# Altium schematic sheet SoC.SchDoc(SoC)
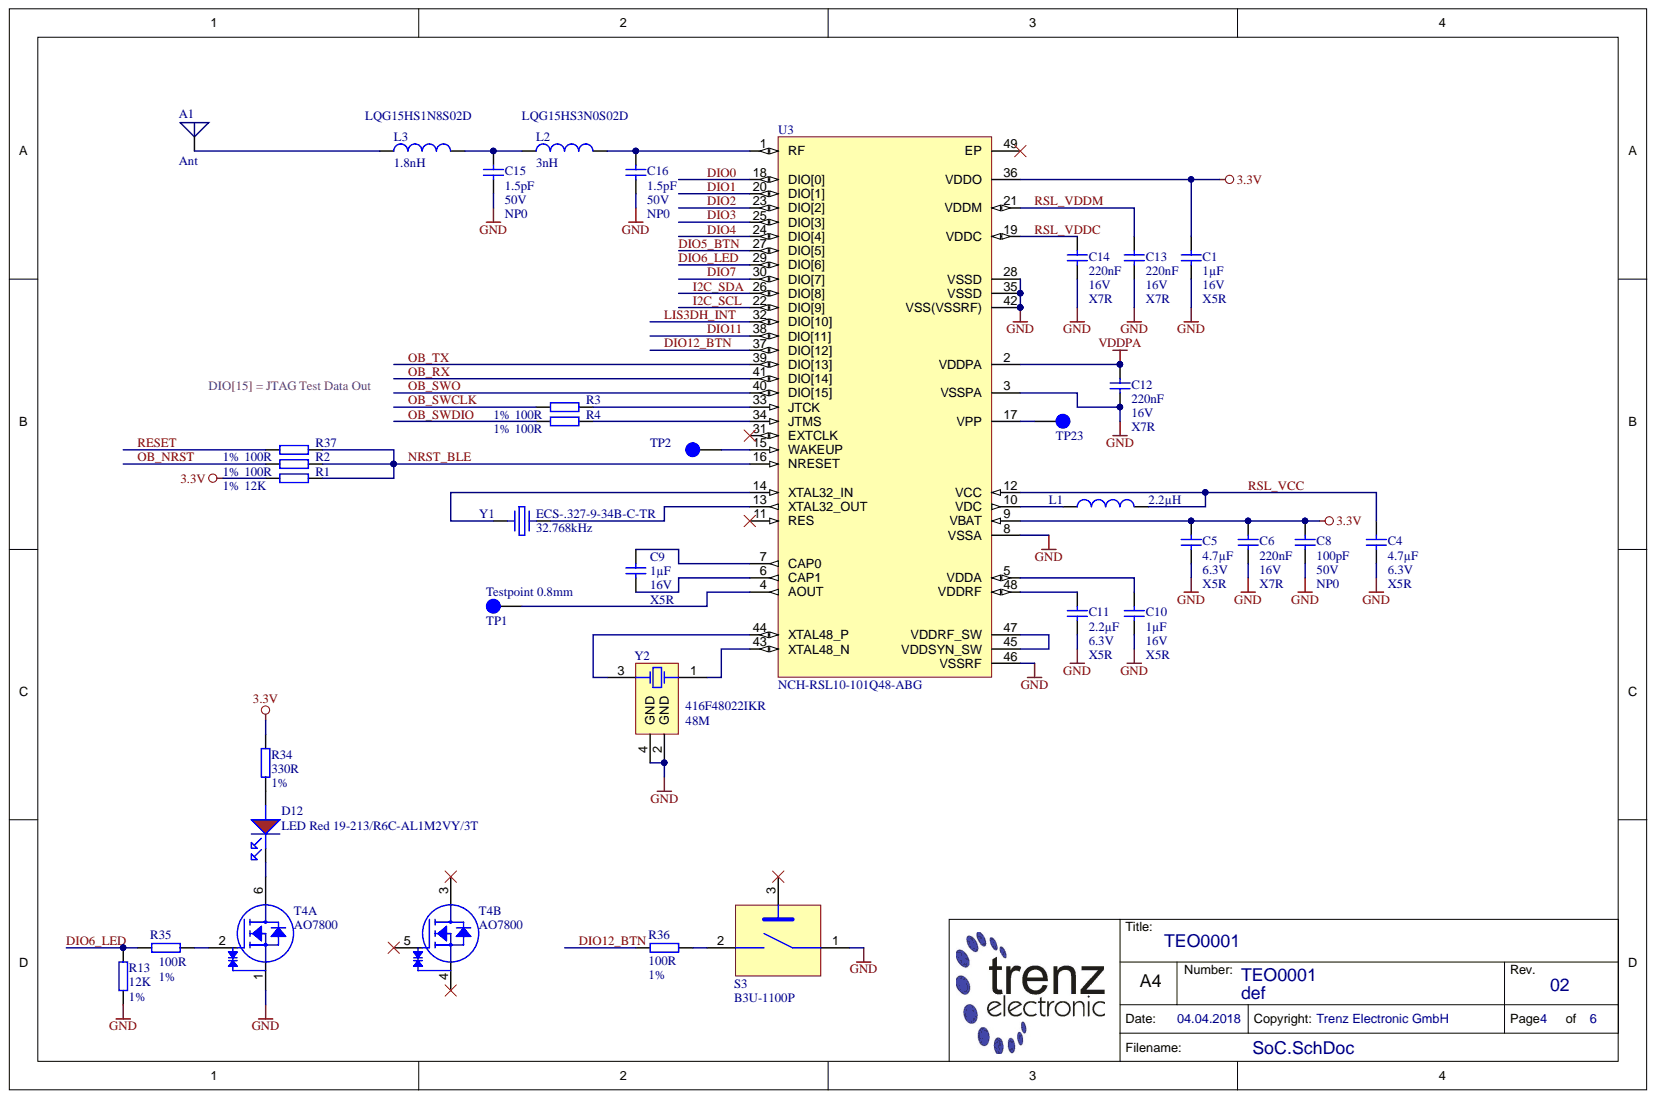
[diagram p0: SoC.SchDoc]

## Parts
A1  Ant  [2.4GHz_PCB_antenna_AN043 inverted]
C1  1µF  Technology: X5R  Voltage: 16V  [CAPC1005X55N]
C4  4.7µF  Technology: X5R  Voltage: 6.3V  [CAPC1005X60N]
C5  4.7µF  Technology: X5R  Voltage: 6.3V  [CAPC1005X60N]
C6  220nF  Technology: X7R  Voltage: 16V  [CAPC1005X55N]
C8  100pF  Technology: NP0  Voltage: 50V  [CAPC1005X55N]
C9  1µF  Technology: X5R  Voltage: 16V  [CAPC1005X55N]
C10  1µF  Technology: X5R  Voltage: 16V  [CAPC1005X55N]
C11  2.2µF  Technology: X5R  Voltage: 6.3V  [CAPC1005X60N]
C12  220nF  Technology: X7R  Voltage: 16V  [CAPC1005X55N]
C13  220nF  Technology: X7R  Voltage: 16V  [CAPC1005X55N]
C14  220nF  Technology: X7R  Voltage: 16V  [CAPC1005X55N]
C15  1.5pF  Technology: NP0  Voltage: 50V  [CAPC1005X55N]
C16  1.5pF  Technology: NP0  Voltage: 50V  [CAPC1005X55N]
D12  LED Red 19-213/R6C-AL1M2VY/3T  Database Table Name: LED  [LEDC1608X55N_RED]
L1  2.2µH  [INDC1608X100N]
L2  3nH  H-ART: LQG15HS3N0S02D  [INDC1005X55N]
L3  1.8nH  H-ART: LQG15HS1N8S02D  [INDC1005X55N]
R1  12K  Tolerance: 1%  [RESC1005X40N]
R2  100R  Tolerance: 1%  [RESC1005X40N]
R3  100R  Tolerance: 1%  [RESC1005X40N]
R4  100R  Tolerance: 1%  [RESC1005X40N]
R13  12K  Tolerance: 1%  [RESC1005X40N]
R34  330R  Tolerance: 1%  [RESC1005X40N]
R35  100R  [RESC1005X40N]
R36  100R  Tolerance: 1%  [RESC1005X40N]
R37  100R  Tolerance: 1%  [RESC1005X40N]
S3  B3U-1100P  [B3U-1100P]
T4  AO7800  [SOT65P210X110-6N]  (parts A,B)
TP1  Testpoint 0.8mm  [TP_0.8mm]
TP2  Testpoint 0.8mm  [TP_0.8mm]
TP23  Testpoint 0.8mm  [TP_0.8mm]
U3  NCH-RSL10-101Q48-ABG  [QFN40P600X600X100-49T450X450N]
Y1  ECS-.327-9-34B-C-TR  value 32.768kHz  [XTAL320X150X90N]
Y2  416F48022IKR  value 48M  [OSCCC160X120X45N]

## Nets
3.3V: C1.1 C5.1 C6.1 C8.1 R1.1 R34.1 U3.9(VBAT) U3.36(VDDO)
GND: C1.2 C4.2 C5.2 C6.2 C8.2 C10.2 C11.2 C12.2 C13.2 C14.2 C15.2 C16.2 R13.1 S3.1 T4.1 U3.3(VSSPA) U3.8(VSSA) U3.28(VSSD) U3.35(VSSD) U3.42(VSS(VSSRF)) U3.46(VSSRF) Y2.2(GND) Y2.4(GND)
VDDPA: C12.1 U3.2(VDDPA)
DIO0: U3.18(DIO[0])
DIO1: U3.20(DIO[1])
DIO2: U3.23(DIO[2])
DIO3: U3.25(DIO[3])
DIO4: U3.24(DIO[4])
DIO5_BTN: U3.27(DIO[5])
DIO6_LED: R13.2 R35.1 U3.29(DIO[6])
DIO7: U3.30(DIO[7])
DIO11: U3.38(DIO[11])
DIO12_BTN: R36.1 U3.37(DIO[12])
I2C_SCL: U3.22(DIO[9])
I2C_SDA: U3.26(DIO[8])
LIS3DH_INT: U3.32(DIO[10])
NRST_BLE: R1.2 R2.2 R37.2 U3.16(NRESET)
OB_NRST: R2.1
OB_RX: U3.41(DIO[14])
OB_SWCLK: R3.1
OB_SWDIO: R4.1
OB_SWO: U3.40(DIO[15])
OB_TX: U3.39(DIO[13])
RESET: R37.1
RSL_VCC: C4.1 L1.2 U3.12(VCC)
RSL_VDDC: C14.1 U3.19(VDDC)
RSL_VDDM: C13.1 U3.21(VDDM)
NetA1_1: A1.1 L3.1
NetC9_1: C9.1 U3.7(CAP0)
NetC9_2: C9.2 U3.6(CAP1)
NetC10_1: C10.1 U3.5(VDDA)
NetC11_1: C11.1 U3.48(VDDRF)
NetC15_1: C15.1 L2.1 L3.2
NetC16_1: C16.1 L2.2 U3.1(RF)
NetD12_A: D12.A R34.2
NetD12_K: D12.K T4.6
NetL1_1: L1.1 U3.10(VDC)
NetR3_2: R3.2 U3.33(JTCK)
NetR4_2: R4.2 U3.34(JTMS)
NetR35_2: R35.2 T4.2
NetR36_2: R36.2 S3.2
NetTP1_1: TP1.1 U3.4(AOUT)
NetTP2_1: TP2.1 U3.15(WAKEUP)
NetTP23_1: TP23.1 U3.17(VPP)
NetU3_13: U3.13(XTAL32_OUT) Y1.2
NetU3_14: U3.14(XTAL32_IN) Y1.1
NetU3_43: U3.43(XTAL48_N) Y2.1
NetU3_44: U3.44(XTAL48_P) Y2.3
NetU3_45: U3.45(VDDSYN_SW) U3.47(VDDRF_SW)

Not connected: S3 3; T4 3 4 5; U3 11(RES) 31(EXTCLK) 49(EP)

## Library data of the parts
C1 C9 C10 = 26579: KON 1µF 16V X5R SMD 10%; Database Table Name: C_1005_0402; Mixed: SMT; Value: 1u; AN: 26579; Case: 1005 (0402); Component Kind: Standard; Library Name: TE.DbLib; Tolerance: 10%
C4 C5 = 24719: KON 4.7µF 6.3V X5R SMD 20%; Database Table Name: C_1005_0402; Mixed: SMT; Preferred: Yes; Value: 4.7u; AN: 24719; Case: 1005 (0402); Component Kind: Standard; Library Name: TE.DbLib; Tolerance: 20%
C6 C12-C14 = 22768: KON 220nF 16V X7R SMD; Database Table Name: C_1005_0402; Mixed: SMT; Value: 220n; AN: 22768; Case: 1005 (0402); Component Kind: Standard; Library Name: TE.DbLib
C8 = 23123: KON 100pF 50V NP0 SMD 5%; Database Table Name: C_1005_0402; Mixed: SMT; Preferred: Yes; Value: 100p; AN: 23123; Case: 1005 (0402); Component Kind: Standard; Library Name: TE.DbLib
C11 = 22749: KON 2,2µF 6,3V X5R SMD; Database Table Name: C_1005_0402; Mixed: SMT; Value: 2.2u; AN: 22749; Case: 1005 (0402); Component Kind: Standard; Library Name: TE.DbLib
C15 C16 = 23128: KON 1.5pF NP0 50V SMD; Database Table Name: C_1005_0402; Mixed: SMT; Value: 1.5p; AN: 23128; Case: 1005 (0402); Component Kind: Standard; Library Name: TE.DbLib
D12 = 28261: Chip LED 0603 Briliant Red; Mixed: SMT; AN: 28261; Case: 1608 (0603); Component Kind: Standard; H-ART: 19-213/R6C-AL1M2VY/3T; Library Name: TE.DbLib; HelpURL: b:\AD\adlib\datasheet\19-213-R6C\
L1 = 28793: IND SMD 2.2µH ±20% 190mA 170mOhm; Database Table Name: L_1608_0603; H-ART: CBMF1608T2R2M; Mixed: SMT; Value: 2.2u; Voltage: 0.19A; AN: 28793; Case: 1608 (0603); Component Kind: Standard; Library Name: TE.DbLib; Technology: 170mΩ; Tolerance: ±20%; HelpURL: B:\AD\adlib\datasheet\TaiyoYurden_CB
L2 = 28792: IND SMD 3nH 800mA 0.125 mΩ; Database Table Name: L_1005_0402; Mixed: SMT; Value: 3n; AN: 28792; Case: 1005 (0402); Component Kind: Standard; Library Name: TE.DbLib; Technology: 0.125 mΩ; Voltage: 800mA; HelpURL: b:\AD\adlib\datasheet\LQG15HS_02\
L3 = 28791: IND SMD 1.8nH 950mA 0.08 Ohms; Database Table Name: L_1005_0402; Mixed: SMT; Value: 1.8n; AN: 28791; Case: 1005 (0402); Component Kind: Standard; Library Name: TE.DbLib; Technology: 0.08 Ohm; Voltage: 950mA; HelpURL: b:\AD\adlib\datasheet\LQG15HS_02\
R1 R13 = 22598: WID SMD 12K 1% 0,063W; Database Table Name: R_1005_0402; Mixed: SMT; Preferred: Yes; AN: 22598; Case: 1005 (0402); Component Kind: Standard; Library Name: TE.DbLib; Technology: TK100; Value: 12K
R2-R4 R35-R37 = 22507: WID SMD 100R 1% 0,063W; Database Table Name: R_1005_0402; Mixed: SMT; Preferred: Yes; Value: 100; AN: 22507; Case: 1005 (0402); Component Kind: Standard; Library Name: TE.DbLib; Technology: TK100
  R35: Tolerance: 1%
R34 = 22762: WID SMD 330R 1% 0,063W; Database Table Name: R_1005_0402; Mixed: SMT; Preferred: Yes; Value: 330; AN: 22762; Case: 1005 (0402); Component Kind: Standard; Library Name: TE.DbLib; Technology: TK100
S3 = 26337: Database Table Name: PB_SW; Mixed: SMT; AN: 26337; Component Kind: Standard; Description: B3U-1100P; H-ART: B3U-1100P; Library Name: TE.DbLib; HelpURL: B:\AD\adlib\datasheet\B3U-1100P
T4 = 28043: Database Table Name: TRANSISTOR; Mixed: SMT; Value: 0.9A; Voltage: 20V; AN: 28043; Case: SOT23-6; Component Kind: Standard; Description: AO7800; H-ART: AO7800; Library Name: TE.DbLib; HelpURL: b:\AD\adlib\datasheet\AO7800\
TP1 TP2 TP23 = 26289: Database Table Name: PCB; Mixed: MECH; AN: 26289; Component Kind: Standard; Description: Testpoint 0.8mm; Library Name: TE.DbLib
U3 = 28742: Database Table Name: IF; Mixed: SMT; AN: 28742; Case: QFN48; Component Kind: Standard; Description: NCH-RSL10-101Q48-ABG; H-ART: NCH-RSL10-101Q48-ABG; Library Name: TE.DbLib; HelpURL: b:\AD\adlib\datasheet\RSL10\
Y1 = 25375: Crystal 32.768kHz ±10ppm 9pF 70kOhm; Mixed: SMT; Trenz-Stock: Yes; AN: 25375; Case: XTAL 3.2 x 1.5mm; Component Kind: Standard; Database Table Name: CRYSTAL; H-ART: ECS-.327-9-34B-C-TR; Library Name: TE.DbLib; HelpURL: B:\AD\adlib\datasheet\ECX-31B
Y2 = 28785: Quarz Crystal 48MHz 8pF SMD; Mixed: SMT; AN: 28785; Case: 1612; Component Kind: Standard; Database Table Name: CRYSTAL; H-ART: 416F48022IKR; Library Name: TE.DbLib; HelpURL: b:\AD\adlib\datasheet\CTS_416F\
A1 = (no symbol name): Component Kind: Standard; Library Name: Antenna.SCHLIB
